# Altium schematic sheet driver.SchDoc
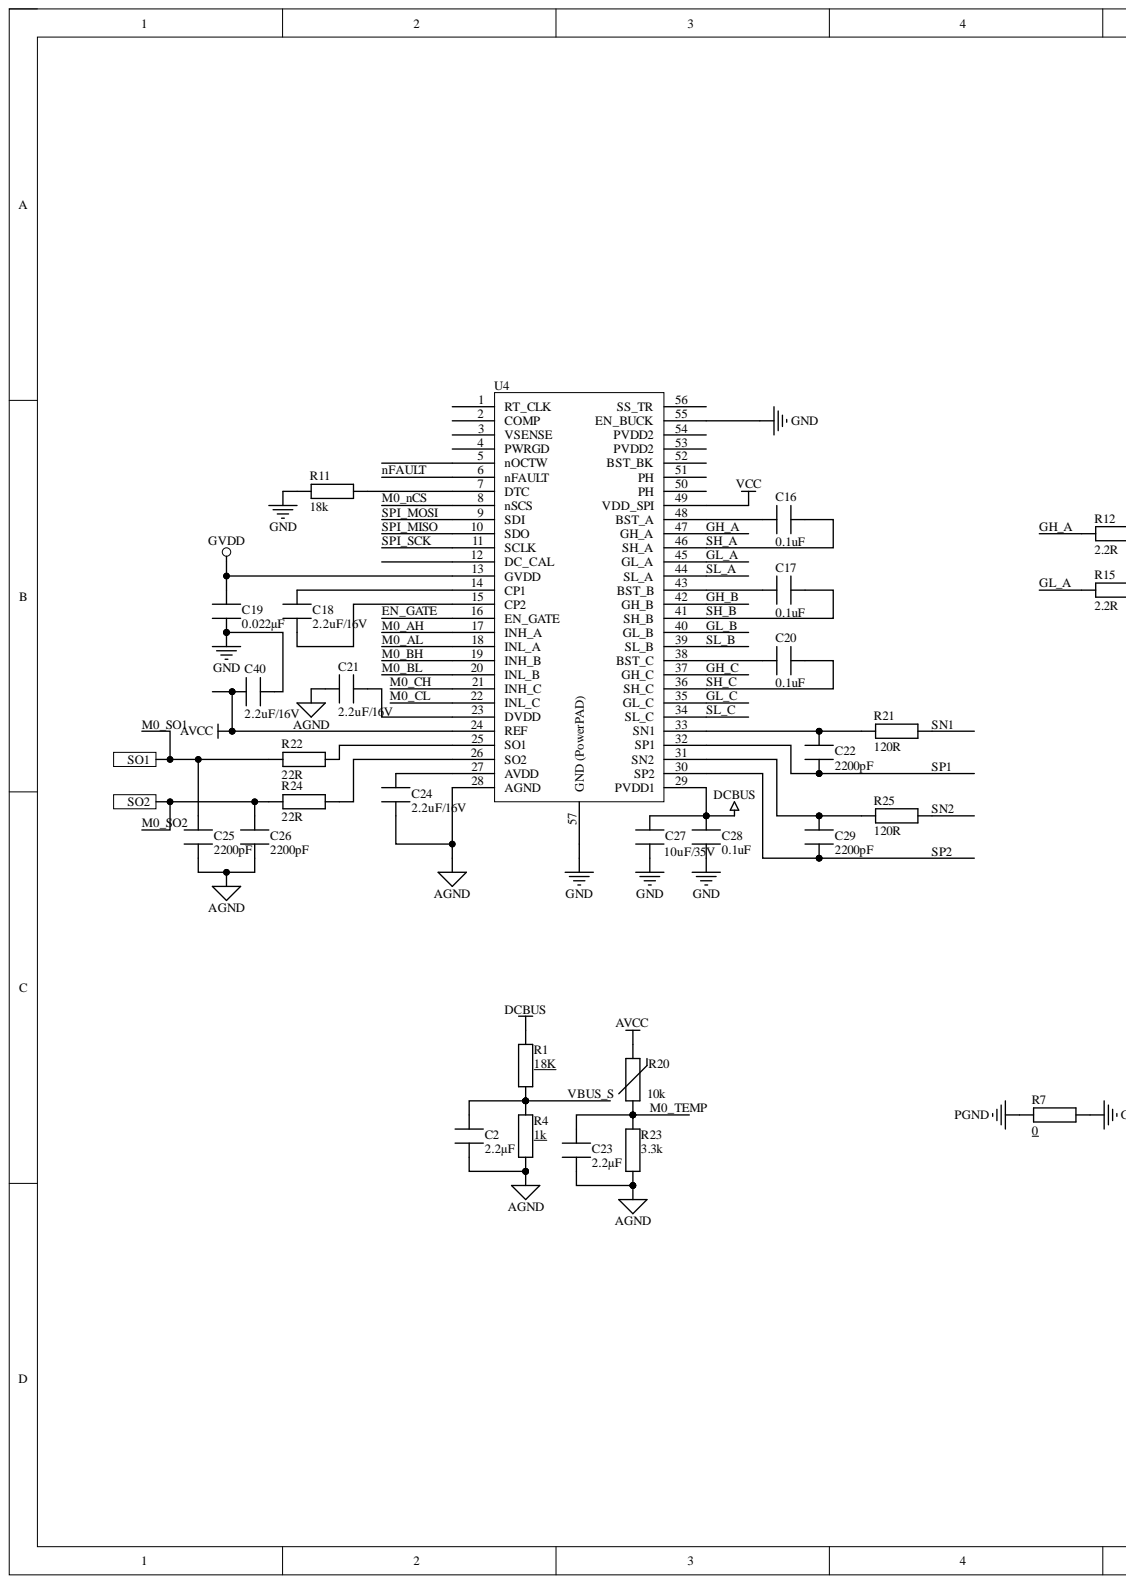
[diagram p0: driver.SchDoc, left]
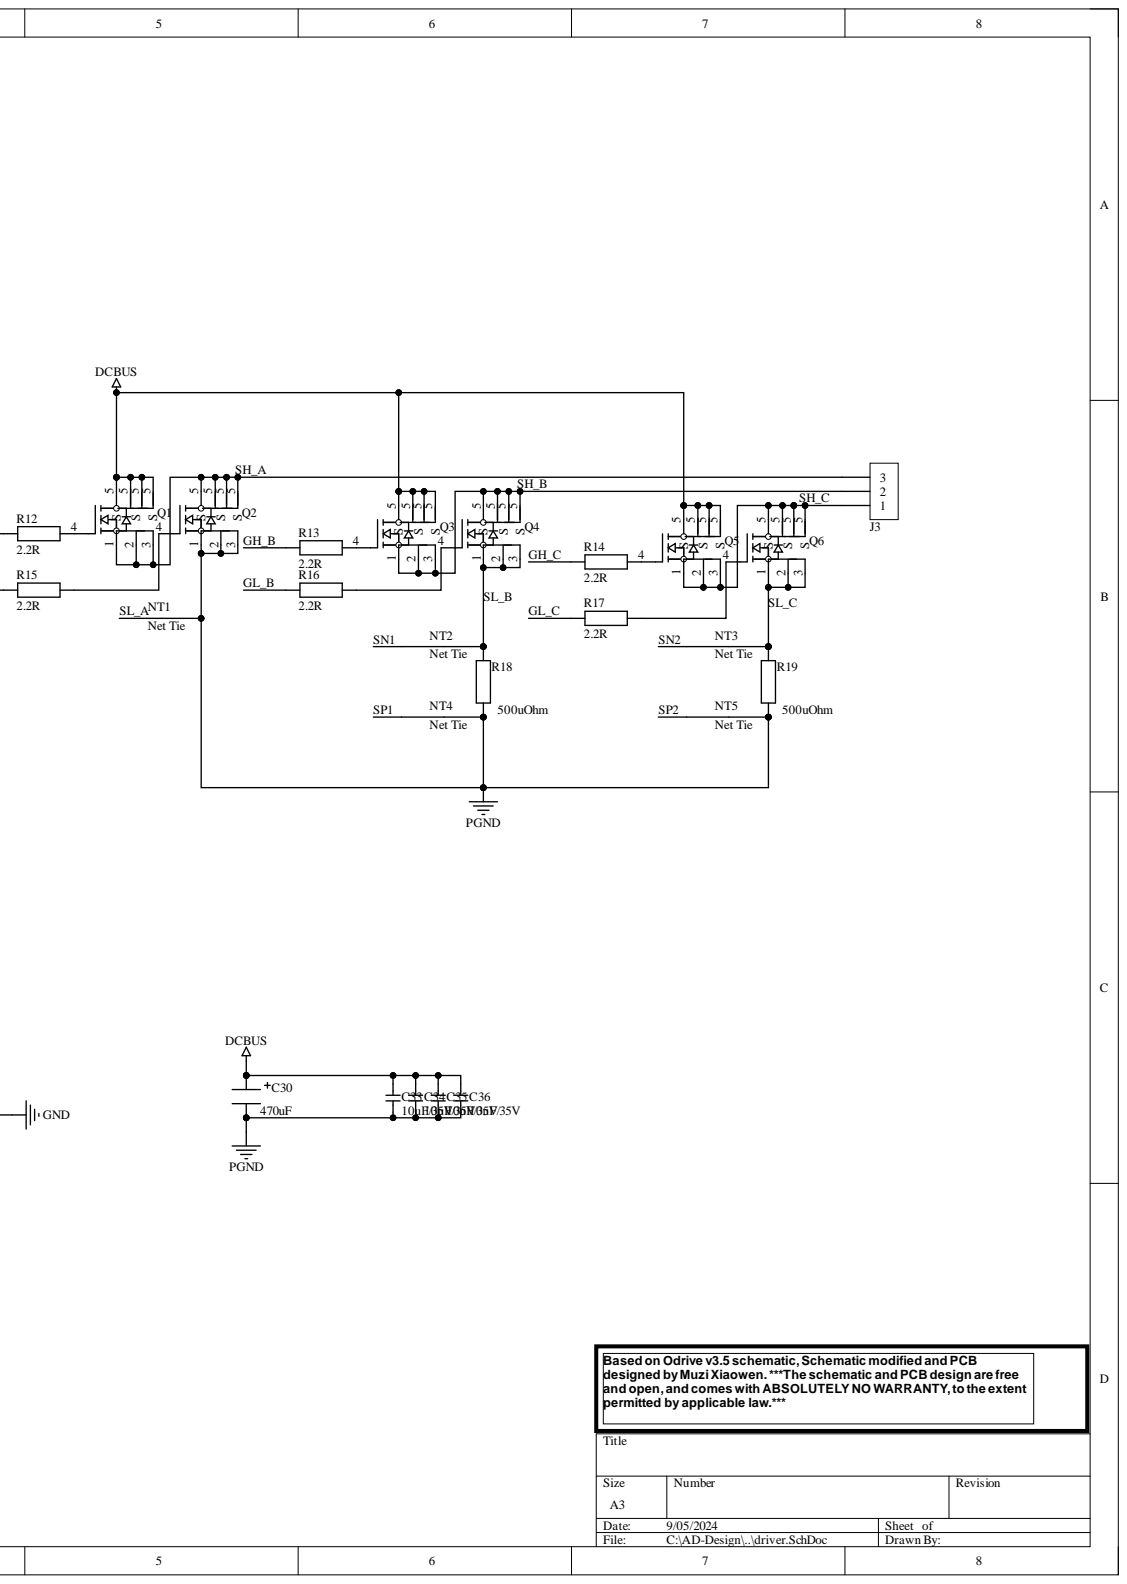
[diagram p1: driver.SchDoc, right]

## Parts
C2  2.2µF  [CAP_0402]
C16  0.1uF  [CAP_0402]
C17  0.1uF  [CAP_0402]
C18  2.2uF/16V  [CAP_0402]
C19  0.022µF  [CAP_0402]
C20  0.1uF  [CAP_0402]
C21  2.2uF/16V  [CAP_0402]
C22  2200pF  [CAP_0402]
C23  2.2µF  [CAP_0402]
C24  2.2uF/16V  [CAP_0402]
C25  2200pF  [CAP_0402]
C26  2200pF  [CAP_0402]
C27  value 10uF/35V  [C0603]  (hidden comment: 4.7uF)
C28  0.1uF  [CAP_0402]
C29  2200pF  [CAP_0402]
C30  470uF  [3d-CAP-SMD_BD6.3-L6.6-W6.6-LS7.2-FD]
C33  10uF/35V  [C0603]
C34  10uF/35V  [C0603]
C35  10uF/35V  [C0603]
C36  10uF/35V  [C0603]
C40  2.2uF/16V  [CAP_0402]
J3  1704936  [MR30PW-3]  (value not drawn)
NT1  0.25mm  [NetTie_0.25mm]  (value not drawn)
NT2  0.25mm  [NetTie_0.25mm]  (value not drawn)
NT3  0.25mm  [NetTie_0.25mm]  (value not drawn)
NT4  0.25mm  [NetTie_0.25mm]  (value not drawn)
NT5  0.25mm  [NetTie_0.25mm]  (value not drawn)
Q1  SE3082G2  [3d-DFN-5_L4.9-W5.9-P1.27-LS6.2-BL]  (value not drawn)
Q2  SE3082G2  [3d-DFN-5_L4.9-W5.9-P1.27-LS6.2-BL]  (value not drawn)
Q3  SE3082G2  [3d-DFN-5_L4.9-W5.9-P1.27-LS6.2-BL]  (value not drawn)
Q4  SE3082G2  [3d-DFN-5_L4.9-W5.9-P1.27-LS6.2-BL]  (value not drawn)
Q5  SE3082G2  [3d-DFN-5_L4.9-W5.9-P1.27-LS6.2-BL]  (value not drawn)
Q6  SE3082G2  [3d-DFN-5_L4.9-W5.9-P1.27-LS6.2-BL]  (value not drawn)
R1  18K  [RES_0402]
R4  1k  [RES_0402]
R7  0  [1206-RES]
R11  18k  [RES_0402]
R12  2.2R  [RES_0402]
R13  2.2R  [RES_0402]
R14  2.2R  [RES_0402]
R15  2.2R  [RES_0402]
R16  2.2R  [RES_0402]
R17  2.2R  [RES_0402]
R18  500uOhm  [RESC6432X09N]
R19  500uOhm  [RESC6432X09N]
R20  10k  [CAP_0402]
R21  120R  [RES_0402]
R22  22R  [RES_0402]
R23  3.3k  [RES_0402]
R24  22R  [RES_0402]
R25  120R  [RES_0402]
U4  (no value)  [TSSOP50P810X120_HS-57N]

## Ports
SO1, SO2

## Nets
AGND: C2.1 C21.2 C23.1 C24.2 C25.2 C26.2 R4.1 R23.2 U4.28(AGND)
AVCC: C40.2 R20.2 U4.24(REF)
DCBUS: C27.2 C28.1 C30.1 C33.2 C34.2 C35.2 C36.2 Q1.5 Q3.5(S) Q5.5(S) R1.2 U4.29(PVDD1)
GND: C19.2 C27.1 C28.2 C40.1 R7.2 R11.2 U4.55(EN_BUCK) U4.57(GND_(PowerPAD))
GVDD: C19.1 U4.13(GVDD)
PGND: C30.2 C33.1 C34.1 C35.1 C36.1 NT1.2 NT4.2 NT5.2 Q2.1(S) Q2.2(S) Q2.3(S) R7.1 R18.1 R19.1
VCC: U4.49(VDD_SPI)
EN_GATE_1: U4.16(EN_GATE)  [also labelled EN_GATE]
GH_A_1: R12.2 U4.47(GH_A)  [also labelled GH_A]
GH_B_1: R13.2 U4.42(GH_B)  [also labelled GH_B]
GH_C_1: R14.2 U4.37(GH_C)  [also labelled GH_C]
GL_A_1: R15.2 U4.45(GL_A)  [also labelled GL_A]
GL_B_1: R16.2 U4.40(GL_B)  [also labelled GL_B]
GL_C_1: R17.2 U4.35(GL_C)  [also labelled GL_C]
M0_AH_1: U4.17(INH_A)  [also labelled M0_AH]
M0_AL_1: U4.18(INL_A)  [also labelled M0_AL]
M0_BH_1: U4.19(INH_B)  [also labelled M0_BH]
M0_BL_1: U4.20(INL_B)  [also labelled M0_BL]
M0_CH_1: U4.21(INH_C)  [also labelled M0_CH]
M0_CL_1: U4.22(INL_C)  [also labelled M0_CL]
M0_nCS_1: U4.8(nSCS)  [also labelled M0_nCS]
M0_SO1: C25.1 R22.2 ; port SO1
M0_SO2: C26.1 R24.2 ; port SO2
M0_TEMP_1: C23.2 R20.1 R23.1  [also labelled M0_TEMP]
nFAULT_1: U4.6(nFAULT)  [also labelled nFAULT]
SH_A_1: C16.1 J3.3 Q1.1(S) Q1.2(S) Q1.3(S) Q2.5 U4.46(SH_A)  [also labelled SH_A]
SH_B_1: C17.1 J3.2 Q3.1 Q3.2(S) Q3.3(S) Q4.5(S) U4.41(SH_B)  [also labelled SH_B]
SH_C_1: C20.1 J3.1 Q5.1 Q5.2(S) Q5.3(S) Q6.5(S) U4.36(SH_C)  [also labelled SH_C]
SL_A_1: NT1.1 U4.44(SL_A)  [also labelled SL_A]
SL_B_1: NT2.2 Q4.1 Q4.2(S) Q4.3(S) R18.2 U4.39(SL_B)  [also labelled SL_B]
SL_C_1: NT3.2 Q6.1 Q6.2(S) Q6.3(S) R19.2 U4.34(SL_C)  [also labelled SL_C]
SN1_1: NT2.1 R21.1  [also labelled SN1]
SN2_1: NT3.1 R25.1  [also labelled SN2]
SP1_1: C22.2 NT4.1 U4.32(SP1)  [also labelled SP1]
SP2_1: C29.2 NT5.1 U4.30(SP2)  [also labelled SP2]
SPI_MISO_1: U4.10(SDO)  [also labelled SPI_MISO]
SPI_MOSI_1: U4.9(SDI)  [also labelled SPI_MOSI]
SPI_SCK_1: U4.11(SCLK)  [also labelled SPI_SCK]
VBUS_S_1: C2.2 R1.1 R4.2  [also labelled VBUS_S]
NetC16_2: C16.2 U4.48(BST_A)
NetC17_2: C17.2 U4.43(BST_B)
NetC18_1: C18.1 U4.15(CP2)
NetC18_2: C18.2 U4.14(CP1)
NetC20_2: C20.2 U4.38(BST_C)
NetC21_1: C21.1 U4.23(DVDD)
NetC22_1: C22.1 R21.2 U4.33(SN1)
NetC24_1: C24.1 U4.27(AVDD)
NetC29_1: C29.1 R25.2 U4.31(SN2)
NetQ1_4: Q1.4 R12.1
NetQ2_4: Q2.4 R15.1
NetQ3_4: Q3.4 R13.1
NetQ4_4: Q4.4 R16.1
NetQ5_4: Q5.4 R14.1
NetQ6_4: Q6.4 R17.1
NetR11_1: R11.1 U4.7(DTC)
NetR22_1: R22.1 U4.25(SO1)
NetR24_1: R24.1 U4.26(SO2)

Not connected: U4 1(RT_CLK) 2(COMP) 3(VSENSE) 4(PWRGD) 5(nOCTW) 12(DC_CAL) 50(PH) 51(PH) 52(BST_BK) 53(PVDD2) 54(PVDD2) 56(SS_TR)

## Library data of the parts
C2 C23 = GRM188R61C225KE15D: CAP CER 2.2UF 16V X5R 0603; Manufacturer: Murata Electronics North America; Tolerance: ±10%; Applications: General Purpose; Capacitance: 2.2µF; Category: Capacitors; Component Kind: Standard; ComponentLink1Description: http://www.murata.com/~/media/webrenewal/support/library/catalog/products/capacitor/mlcc/c02e.pdf; ComponentLink1URL: http://www.murata.com/~/media/webrenewal/support/library/catalog/products/capacitor/mlcc/c02e.pdf; Features: -; Height - Seated (Max): -; Lead Spacing: -; Lead Style: -; Library Name: CustomComponents.SchLib; Manufacturer Part Number: GRM188R61C225KE15D; Mounting Type: Surface Mount, MLCC; Operating Temperature: -55°C ~ 85°C; Package / Case: 0603 (1608 Metric); Packaging: Cut Tape (CT); Pricing 1: 1=0.12, 10=0.08, 50=0.046, 100=0.038, 250=0.032, 500=0.0268, 1000=0.022 (USD); Ratings: -; RoHS: RoHS Compliant; Size / Dimension: 0.063" L x 0.031" W (1.60mm x 0.80mm); Stock 1: 1255740; Supplier 1: Digi-Key; Supplier Part Number 1: 490-3296-1-ND; Temperature Coefficient: X5R; Thickness (Max): 0.035" (0.90mm); Voltage - Rated: 16V
C16 C17 C20 C28 = GRM188R71H104KA93D: CAP CER 0.1UF 50V X7R 0603; Manufacturer: Murata Electronics North America; Tolerance: ±10%; Applications: General Purpose; Capacitance: 0.1uF; Category: Capacitors; Component Kind: Standard; ComponentLink1Description: http://www.murata.com/~/media/webrenewal/support/library/catalog/products/capacitor/mlcc/c02e.ashx?la=en-us; ComponentLink1URL: http://www.murata.com/~/media/webrenewal/support/library/catalog/products/capacitor/mlcc/c02e.ashx?la=en-us; Features: -; Height - Seated (Max): -; Lead Spacing: -; Lead Style: -; Library Name: CustomComponents.SchLib; Manufacturer Part Number: GRM188R71H104KA93D; Mounting Type: Surface Mount, MLCC; Operating Temperature: -55°C ~ 125°C; Package / Case: 0603 (1608 Metric); Packaging: Tape & Reel (TR); Part Status: Active; Pricing 1: 4000=0.01822, 8000=0.01663, 12000=0.01584 (USD); Pricing 2: 1=0.12, 10=0.084, 100=0.0396, 500=0.02778, 1000=0.023 (USD); Ratings: -; RoHS: RoHS Compliant; Size / Dimension: 0.063" L x 0.032" W (1.60mm x 0.80mm); Stock: 19812000; Supplier 1: Digi-Key; Supplier 2: Digi-Key; Supplier Part Number 1: 490-1519-2-ND; Supplier Part Number 2: 490-1519-1-ND; Temperature Coefficient: X7R; Thickness (Max): 0.035" (0.90mm); Voltage - Rated: 50V
C18 C21 C24 C40 = GRM188R61C225KE15D: CAP CER 2.2UF 16V X5R 0603; Manufacturer: Murata Electronics North America; Capacitance: 2.2µF; Tolerance: ±10%; Applications: General Purpose; Category: Capacitors; Component Kind: Standard; ComponentLink1Description: http://www.murata.com/~/media/webrenewal/support/library/catalog/products/capacitor/mlcc/c02e.pdf; ComponentLink1URL: http://www.murata.com/~/media/webrenewal/support/library/catalog/products/capacitor/mlcc/c02e.pdf; Features: -; Height - Seated (Max): -; Lead Spacing: -; Lead Style: -; Library Name: CustomComponents.SchLib; Manufacturer Part Number: GRM188R61C225KE15D; Mounting Type: Surface Mount, MLCC; Operating Temperature: -55°C ~ 85°C; Package / Case: 0603 (1608 Metric); Packaging: Cut Tape (CT); Pricing 1: 1=0.12, 10=0.08, 50=0.046, 100=0.038, 250=0.032, 500=0.0268, 1000=0.022 (USD); Ratings: -; RoHS: RoHS Compliant; Size / Dimension: 0.063" L x 0.031" W (1.60mm x 0.80mm); Stock 1: 1255740; Supplier 1: Digi-Key; Supplier Part Number 1: 490-3296-1-ND; Temperature Coefficient: X5R; Thickness (Max): 0.035" (0.90mm); Voltage - Rated: 16V
C19 = GRM188R71H223KA01D: CAP CER 0.022UF 50V X7R 0603; Manufacturer: Murata Electronics North America; Tolerance: ±10%; Applications: General Purpose; Capacitance: 0.022µF; Category: Capacitors; Component Kind: Standard; ComponentLink1Description: http://search.murata.co.jp/Ceramy/image/img/A01X/G101/ENG/GRM188R71H223KA01-01.pdf; ComponentLink1URL: http://search.murata.co.jp/Ceramy/image/img/A01X/G101/ENG/GRM188R71H223KA01-01.pdf; Features: -; Height - Seated (Max): -; Lead Spacing: -; Lead Style: -; Library Name: CustomComponents.SchLib; Manufacturer Part Number: GRM188R71H223KA01D; Mounting Type: Surface Mount, MLCC; Operating Temperature: -55°C ~ 125°C; Package / Case: 0603 (1608 Metric); Packaging: Tape & Reel (TR); Part Status: Active; Pricing 1: 4000=0.01518, 8000=0.01386, 12000=0.0132 (USD); Pricing 2: 1=0.11, 10=0.083, 100=0.0372, 500=0.02656, 1000=0.02087 (USD); Ratings: -; RoHS: RoHS Compliant; Size / Dimension: 0.063" L x 0.032" W (1.60mm x 0.80mm); Stock: 608000; Supplier 1: Digi-Key; Supplier 2: Digi-Key; Supplier Part Number 1: 490-1517-2-ND; Supplier Part Number 2: 490-1517-1-ND; Temperature Coefficient: X7R; Thickness (Max): 0.035" (0.90mm); Voltage - Rated: 50V
C22 C25 C26 C29 = GRM1885C1H222JA01D: CAP CER 2200PF 50V NP0 0603; Manufacturer: Murata Electronics North America; Temperature Coefficient: C0G, NP0; Tolerance: ±5%; Applications: General Purpose; Capacitance: 2200pF; Category: Capacitors; Component Kind: Standard; ComponentLink1Description: http://www.murata.com/~/media/webrenewal/support/library/catalog/products/capacitor/mlcc/c02e.ashx; ComponentLink1URL: http://www.murata.com/~/media/webrenewal/support/library/catalog/products/capacitor/mlcc/c02e.ashx; Features: -; Height - Seated (Max): -; Lead Spacing: -; Lead Style: -; Library Name: CustomComponents.SchLib; Mounting Type: Surface Mount, MLCC; Operating Temperature: -55°C ~ 125°C; Package / Case: 0603 (1608 Metric); Packaging: Cut Tape (CT); Part Status: Active; Pricing 1: 1=0.11, 10=0.08, 25=0.058, 50=0.0434, 100=0.0355, 250=0.02972, 500=0.02536, 1000=0.01992 (USD); Ratings: -; RoHS: RoHS Compliant; Size / Dimension: 0.063" L x 0.031" W (1.60mm x 0.80mm); Stock 1: 850589; Supplier 1: Digi-Key; Supplier Part Number 1: 490-1459-1-ND; Thickness (Max): 0.035" (0.90mm); Voltage - Rated: 50V
C27 = GRM219R6YA475KA73D: CAP CER 4.7UF 35V X5R 0805; Manufacturer: Murata Electronics North America; Tolerance: ±10%; Applications: General Purpose; Category: Capacitors; Component Kind: Standard; ComponentLink1Description: http://www.murata.com/~/media/webrenewal/support/library/catalog/products/capacitor/mlcc/c02e.ashx?la=en-us; ComponentLink1URL: http://www.murata.com/~/media/webrenewal/support/library/catalog/products/capacitor/mlcc/c02e.ashx?la=en-us; Features: Derating Recommended; Height - Seated (Max): -; Lead Spacing: -; Lead Style: -; Library Name: CustomComponents.SchLib; Manufacturer Part Number: GRM219R6YA475KA73D; Mounting Type: Surface Mount, MLCC; Operating Temperature: -55°C ~ 85°C; Package / Case: 0805 (2012 Metric); Packaging: Tape & Reel (TR); Part Status: Active; Pricing 1: 4000=0.05126, 8000=0.0487, 12000=0.04613 (USD); Pricing 2: 1=0.26, 10=0.177, 100=0.1002, 500=0.07076, 1000=0.06191 (USD); Ratings: -; RoHS: RoHS Compliant; Size / Dimension: 0.079" L x 0.049" W (2.01mm x 1.25mm); Stock: 152000; Supplier 1: Digi-Key; Supplier 2: Digi-Key; Supplier Part Number 1: 490-7208-2-ND; Supplier Part Number 2: 490-7208-1-ND; Temperature Coefficient: X5R; Thickness (Max): 0.037" (0.95mm); Voltage - Rated: 35V
C30 = EEEFP1E471AP: CAP, FP Series, 470 µF, 25 V, Radial Can - SMD, 10 mm; Manufacturer: Panasonic Electronic Components; Manufacturer Part Number: EEE-FP1E471AP; ESR (Equivalent Series Resistance): 60 mOhm @ 100kHz; Lifetime @ Temp.: 2000 Hrs @ 105°C; Polarization: Polar; Ripple Current - High Frequency: 1.19A @ 100kHz; Ripple Current - Low Frequency: 773.5mA @ 120Hz; Surface Mount Land Size: 0.406" L x 0.406" W (10.30mm x 10.30mm); Tolerance: ±20%; Applications: Automotive; Category: Capacitors; Component Kind: Standard; ComponentLink1Description: http://industrial.panasonic.com/www-cgi/jvcr13pz.cgi?E+PZ+3+ABA0114+EEEFP1E471AP+7+WW; ComponentLink1URL: http://industrial.panasonic.com/www-cgi/jvcr13pz.cgi?E+PZ+3+ABA0114+EEEFP1E471AP+7+WW; Height - Seated (Max): 0.402" (10.20mm); Lead Spacing: -; Library Name: CustomComponents.SchLib; Mounting Type: Surface Mount; Operating Temperature: -55°C ~ 105°C; Package / Case: Radial, Can - SMD; Packaging: Tape & Reel (TR); Part Status: Active; Pricing 1: 500=0.3571, 1000=0.30072, 2500=0.28192, 5000=0.26313, 12500=0.24997, 25000=0.24434 (USD); Pricing 2: 1=0.92, 10=0.702, 100=0.5025 (USD); RoHS: RoHS Compliant; Size / Dimension: 0.394" Dia (10.00mm); Stock: 8000; Supplier 1: Digi-Key; Supplier 2: Digi-Key; Supplier Part Number 1: PCE4440TR-ND; Supplier Part Number 2: PCE4440CT-ND; Value: 470uF
C33-C36 = 电容-0402: Capacitor; Value: 22uF 35V; Component Kind: Standard; Library Name: MyPcbLib.IntLib
J3 = 1704936: CONN TERM BLOCK 3POS 7.62MM; Manufacturer: Phoenix Contact; Current: 30A; Mating Orientation: Horizontal with Board; Number of Levels: 1; Pitch: 0.300" (7.62mm); Positions Per Level: 3; Screw Thread: M3; Torque - Screw: 0.5-0.6 Nm (4.4-5.3 Lb-In); Voltage: 300V; Wire Gauge: 10-30 AWG; Wire Termination: Screw - Rising Cage Clamp; Category: Connectors, Interconnects; Clamp Material - Plating: -; Color: Green; Component Kind: Standard; ComponentLink1Description: http://media.digikey.com/PDF/Data%20Sheets/Phoenix%20Contact%20PDFs/1704936.pdf; ComponentLink1URL: http://media.digikey.com/PDF/Data%20Sheets/Phoenix%20Contact%20PDFs/1704936.pdf; Contact Material - Plating: -; Features: Interlocking (Side); Housing Material: Polyamide (PA), Nylon; Library Name: CustomComponents.SchLib; Manufacturer Part Number: 1704936; Mounting Type: Through Hole; Operating Temperature: -; Packaging: Bulk; Part Status: Active; Pricing 1: 1=2.19, 10=2.128, 50=1.989, 100=1.8299, 250=1.69064, 500=1.61108, 1000=1.482 (USD); RoHS: RoHS Compliant; Screw Material - Plating: -; Stock: 3559; Supplier 1: Digi-Key; Supplier Part Number 1: 277-5954-ND
NT1-NT5 = NetTie_0.25mm: Component Kind: Net Tie; Library Name: CustomComponents.SchLib
Q1-Q6 = SE3082G2: MOSFET N-CH 30V 13A SO8FL; Manufacturer: ON Semiconductor; Manufacturer Part Number: NTMFS4935NT1G; Current - Continuous Drain (Id) @ 25°C: 13A (Ta), 93A (Tc); Drive Voltage (Max Rds On,  Min Rds On): 4.5V, 10V; FET Type: N-Channel; Gate Charge (Qg) (Max) @ Vgs: 49.4nC @ 10V; Input Capacitance (Ciss) (Max) @ Vds: 4850pF @ 15V; Power Dissipation (Max): 930mW (Ta), 48W (Tc); Rds On (Max) @ Id, Vgs: 3.2 mOhm @ 30A, 10V; Vgs (Max): ±20V; Vgs(th) (Max) @ Id: 2.2V @ 250µA; Category: Discrete Semiconductor Products; Component Kind: Standard; ComponentLink1Description: http://www.onsemi.com/pub_link/Collateral/NTMFS4935N-D.PDF; ComponentLink1URL: http://www.onsemi.com/pub_link/Collateral/NTMFS4935N-D.PDF; Drain to Source Voltage (Vdss): 30V; FET Feature: -; Mounting Type: Surface Mount; Operating Temperature: -55°C ~ 150°C (TJ); Package / Case: 8-PowerTDFN, 5 Leads; Packaging: Cut Tape (CT); Part Status: Active; Pricing 1: 1=0.61, 10=0.541, 100=0.4145, 500=0.3277 (USD); RoHS: RoHS Compliant; Stock 1: 932; Supplier 1: Digi-Key; Supplier Device Package: 5-DFN (5x6) (8-SOFL); Supplier Part Number 1: NTMFS4935NT1GOSCT-ND; Technology: MOSFET (Metal Oxide); Library Name: odrivelib.SchLib
R1 R4 R7 = RC0603FR-071KL: RES SMD 1K OHM 1% 1/10W 0603; Manufacturer: Yageo; Power (Watts): 0.1W, 1/10W; Temperature Coefficient: ±100ppm/°C; Category: Resistors; Component Kind: Standard; ComponentLink1Description: http://www.yageo.com/documents/recent/PYu-RC_Group_51_RoHS_L_04.pdf; ComponentLink1URL: http://www.yageo.com/documents/recent/PYu-RC_Group_51_RoHS_L_04.pdf; Composition: Thick Film; Features: Moisture Resistant; Height: 0.022" (0.55mm); Library Name: CustomComponents.SchLib; Manufacturer Part Number: RC0603FR-071KL; Number of Terminations: 2; Operating Temperature: -55°C ~ 155°C; Package / Case: 0603 (1608 Metric); Packaging: Cut Tape (CT); Pricing 1: 1=0.1, 10=0.014, 25=0.01, 100=0.0057, 250=0.00436, 500=0.00348, 1000=0.00257, 2500=0.00223 (USD); Resistance (Ohms): 1k; RoHS: RoHS Compliant; Size / Dimension: 0.063" L x 0.031" W (1.60mm x 0.80mm); Stock 1: 6734836; Supplier 1: Digi-Key; Supplier Device Package: 0603; Supplier Part Number 1: 311-1.00KHRCT-ND; Tolerance: ±1%
R11 = RC0603FR-0718KL: RES SMD 18K OHM 1% 1/10W 0603; Manufacturer: Yageo; Power (Watts): 0.1W, 1/10W; Temperature Coefficient: ±100ppm/°C; Category: Resistors; Component Kind: Standard; ComponentLink1Description: http://www.yageo.com/documents/recent/PYu-RC_Group_51_RoHS_L_04.pdf; ComponentLink1URL: http://www.yageo.com/documents/recent/PYu-RC_Group_51_RoHS_L_04.pdf; Composition: Thick Film; Features: Moisture Resistant; Height: 0.022" (0.55mm); Library Name: CustomComponents.SchLib; Manufacturer Part Number: RC0603FR-0718KL; Number of Terminations: 2; Operating Temperature: -55°C ~ 155°C; Package / Case: 0603 (1608 Metric); Packaging: Cut Tape (CT); Pricing 1: 1=0.1, 10=0.014, 25=0.01, 100=0.0057, 250=0.00436, 500=0.00348, 1000=0.00257, 2500=0.00223 (USD); Resistance (Ohms): 18k; RoHS: RoHS Compliant; Size / Dimension: 0.063" L x 0.031" W (1.60mm x 0.80mm); Stock 1: 0; Supplier 1: Digi-Key; Supplier Device Package: 0603; Supplier Part Number 1: 311-18.0KHRCT-ND; Tolerance: ±1%
R12-R17 = RC0603FR-072R2L: RES SMD 2.2 OHM 1% 1/10W 0603; Manufacturer: Yageo; Power (Watts): 0.1W, 1/10W; Resistance (Ohms): 2.2; Temperature Coefficient: ±200ppm/°C; Category: Resistors; Component Kind: Standard; ComponentLink1Description: http://www.yageo.com/documents/recent/PYu-RC_Group_51_RoHS_L_04.pdf; ComponentLink1URL: http://www.yageo.com/documents/recent/PYu-RC_Group_51_RoHS_L_04.pdf; Composition: Thick Film; Features: Moisture Resistant; Height: 0.022" (0.55mm); Library Name: CustomComponents.SchLib; Manufacturer Part Number: RC0603FR-072R2L; Number of Terminations: 2; Operating Temperature: -55°C ~ 155°C; Package / Case: 0603 (1608 Metric); Packaging: Cut Tape (CT); Pricing 1: 1=0.1, 10=0.02, 25=0.0144, 100=0.0082, 250=0.00624, 500=0.00498, 1000=0.00367, 2500=0.00319 (USD); RoHS: RoHS Compliant; Size / Dimension: 0.063" L x 0.031" W (1.60mm x 0.80mm); Stock 1: 16531; Supplier 1: Digi-Key; Supplier Device Package: 0603; Supplier Part Number 1: 311-2.2HRCT-ND; Tolerance: ±1%
R18 R19 = CSR2512C0R0005F: RES SMD 0.0005 OHM 1% 3W 2512; Manufacturer: Riedon; Temperature Coefficient: ±100ppm/°C; Category: Resistors; Component Kind: Standard; ComponentLink1Description: http://riedon.com/media/pdf/CSR.pdf; ComponentLink1URL: http://riedon.com/media/pdf/CSR.pdf; Composition: Metal Element; Failure Rate: -; Features: Current Sense; Height - Seated (Max): 0.057" (1.45mm); Library Name: CustomComponents.SchLib; Manufacturer Part Number: CSR2512C0R0005F; Operating Temperature: -55°C ~ 170°C; Package / Case: 2512 (6432 Metric); Packaging: Tape & Reel (TR); Part Status: Active; Power (Watts): 3W; Pricing 1: 2000=0.19817, 6000=0.1937, 10000=0.187, 50000=0.18148 (USD); Pricing 2: 1=0.69, 10=0.574, 25=0.4916, 50=0.4426, 100=0.3852, 250=0.3442, 500=0.27864, 1000=0.22536 (USD); RoHS: RoHS Compliant; Size / Dimension: 0.250" L x 0.118" W (6.35mm x 3.00mm); Stock: 2000; Supplier 1: Digi-Key; Supplier 2: Digi-Key; Supplier Device Package: 2512; Supplier Part Number 1: 696-1183-2-ND; Supplier Part Number 2: 696-1183-1-ND; Tolerance: ±1%; Value: 500uOhm
R20 = NCP15XH103F03RC: THERMISTOR 10K OHM NTC 0402 SMD; Component Kind: Standard; Library Name: CustomComponents.SchLib; Value: 10k
R21 R25 = RC0603FR-07120RL: RES SMD 120 OHM 1% 1/10W 0603; Manufacturer: Yageo; Power (Watts): 0.1W, 1/10W; Resistance (Ohms): 120; Temperature Coefficient: ±100ppm/°C; Category: Resistors; Component Kind: Standard; ComponentLink1Description: http://www.yageo.com/documents/recent/PYu-RC_Group_51_RoHS_L_04.pdf; ComponentLink1URL: http://www.yageo.com/documents/recent/PYu-RC_Group_51_RoHS_L_04.pdf; Composition: Thick Film; Features: Moisture Resistant; Height: 0.022" (0.55mm); Library Name: CustomComponents.SchLib; Manufacturer Part Number: RC0603FR-07120RL; Number of Terminations: 2; Operating Temperature: -55°C ~ 155°C; Package / Case: 0603 (1608 Metric); Packaging: Cut Tape (CT); Pricing 1: 1=0.1, 10=0.014, 25=0.01, 100=0.0057, 250=0.00436, 500=0.00348, 1000=0.00257, 2500=0.00223 (USD); RoHS: RoHS Compliant; Size / Dimension: 0.063" L x 0.031" W (1.60mm x 0.80mm); Stock 1: 1545282; Supplier 1: Digi-Key; Supplier Device Package: 0603; Supplier Part Number 1: 311-120HRCT-ND; Tolerance: ±1%
R22 R24 = RC0603FR-0722RL: RES SMD 22 OHM 1% 1/10W 0603; Manufacturer: Yageo; Power (Watts): 0.1W, 1/10W; Resistance (Ohms): 22; Temperature Coefficient: ±100ppm/°C; Category: Resistors; Component Kind: Standard; ComponentLink1Description: http://www.yageo.com/documents/recent/PYu-RC_Group_51_RoHS_L_04.pdf; ComponentLink1URL: http://www.yageo.com/documents/recent/PYu-RC_Group_51_RoHS_L_04.pdf; Composition: Thick Film; Features: Moisture Resistant; Height: 0.022" (0.55mm); Library Name: CustomComponents.SchLib; Manufacturer Part Number: RC0603FR-0722RL; Number of Terminations: 2; Operating Temperature: -55°C ~ 155°C; Package / Case: 0603 (1608 Metric); Packaging: Cut Tape (CT); Pricing 1: 1=0.1, 10=0.014, 25=0.01, 100=0.0057, 250=0.00436, 500=0.00348, 1000=0.00257, 2500=0.00223 (USD); RoHS: RoHS Compliant; Size / Dimension: 0.063" L x 0.031" W (1.60mm x 0.80mm); Stock 1: 575303; Supplier 1: Digi-Key; Supplier Device Package: 0603; Supplier Part Number 1: 311-22.0HRCT-ND; Tolerance: ±1%
R23 = RC0603FR-073K3L: RES SMD 3.3K OHM 1% 1/10W 0603; Manufacturer: Yageo; Power (Watts): 0.1W, 1/10W; Temperature Coefficient: ±100ppm/°C; Category: Resistors; Component Kind: Standard; ComponentLink1Description: http://www.yageo.com/documents/recent/PYu-RC_Group_51_RoHS_L_04.pdf; ComponentLink1URL: http://www.yageo.com/documents/recent/PYu-RC_Group_51_RoHS_L_04.pdf; Composition: Thick Film; Features: Moisture Resistant; Height: 0.022" (0.55mm); Library Name: CustomComponents.SchLib; Manufacturer Part Number: RC0603FR-073K3L; Number of Terminations: 2; Operating Temperature: -55°C ~ 155°C; Package / Case: 0603 (1608 Metric); Packaging: Cut Tape (CT); Pricing 1: 1=0.1, 10=0.014, 25=0.01, 100=0.0057, 250=0.00436, 500=0.00348, 1000=0.00257, 2500=0.00223 (USD); Resistance (Ohms): 3.3k; RoHS: RoHS Compliant; Size / Dimension: 0.063" L x 0.031" W (1.60mm x 0.80mm); Stock 1: 420019; Supplier 1: Digi-Key; Supplier Device Package: 0603; Supplier Part Number 1: 311-3.30KHRCT-ND; Tolerance: ±1%
U4 = DRV8301: MOTOR DRIVER, 3PH, 56HTSSOP; Component Kind: Standard; Library Name: CustomComponents.SchLib
